# Altium schematic sheet System TopSheet v2.SchDoc("System TopSheet v2")
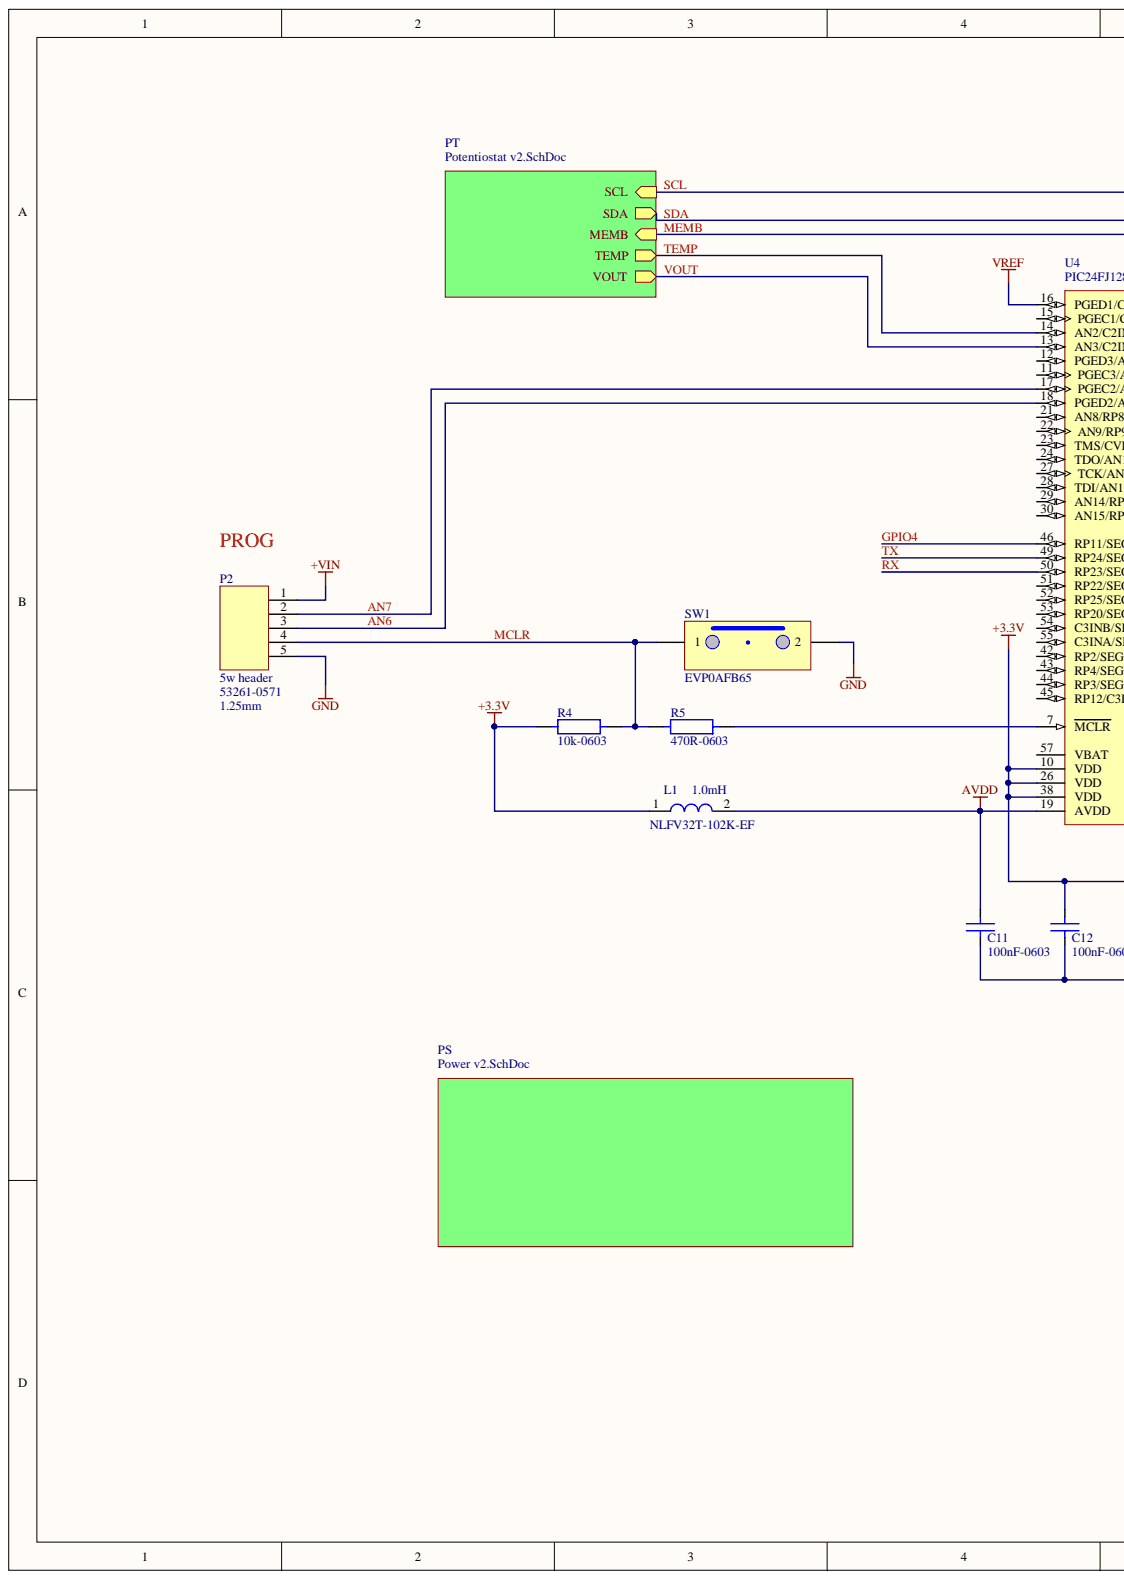
[diagram p0: System TopSheet v2.SchDoc, left]
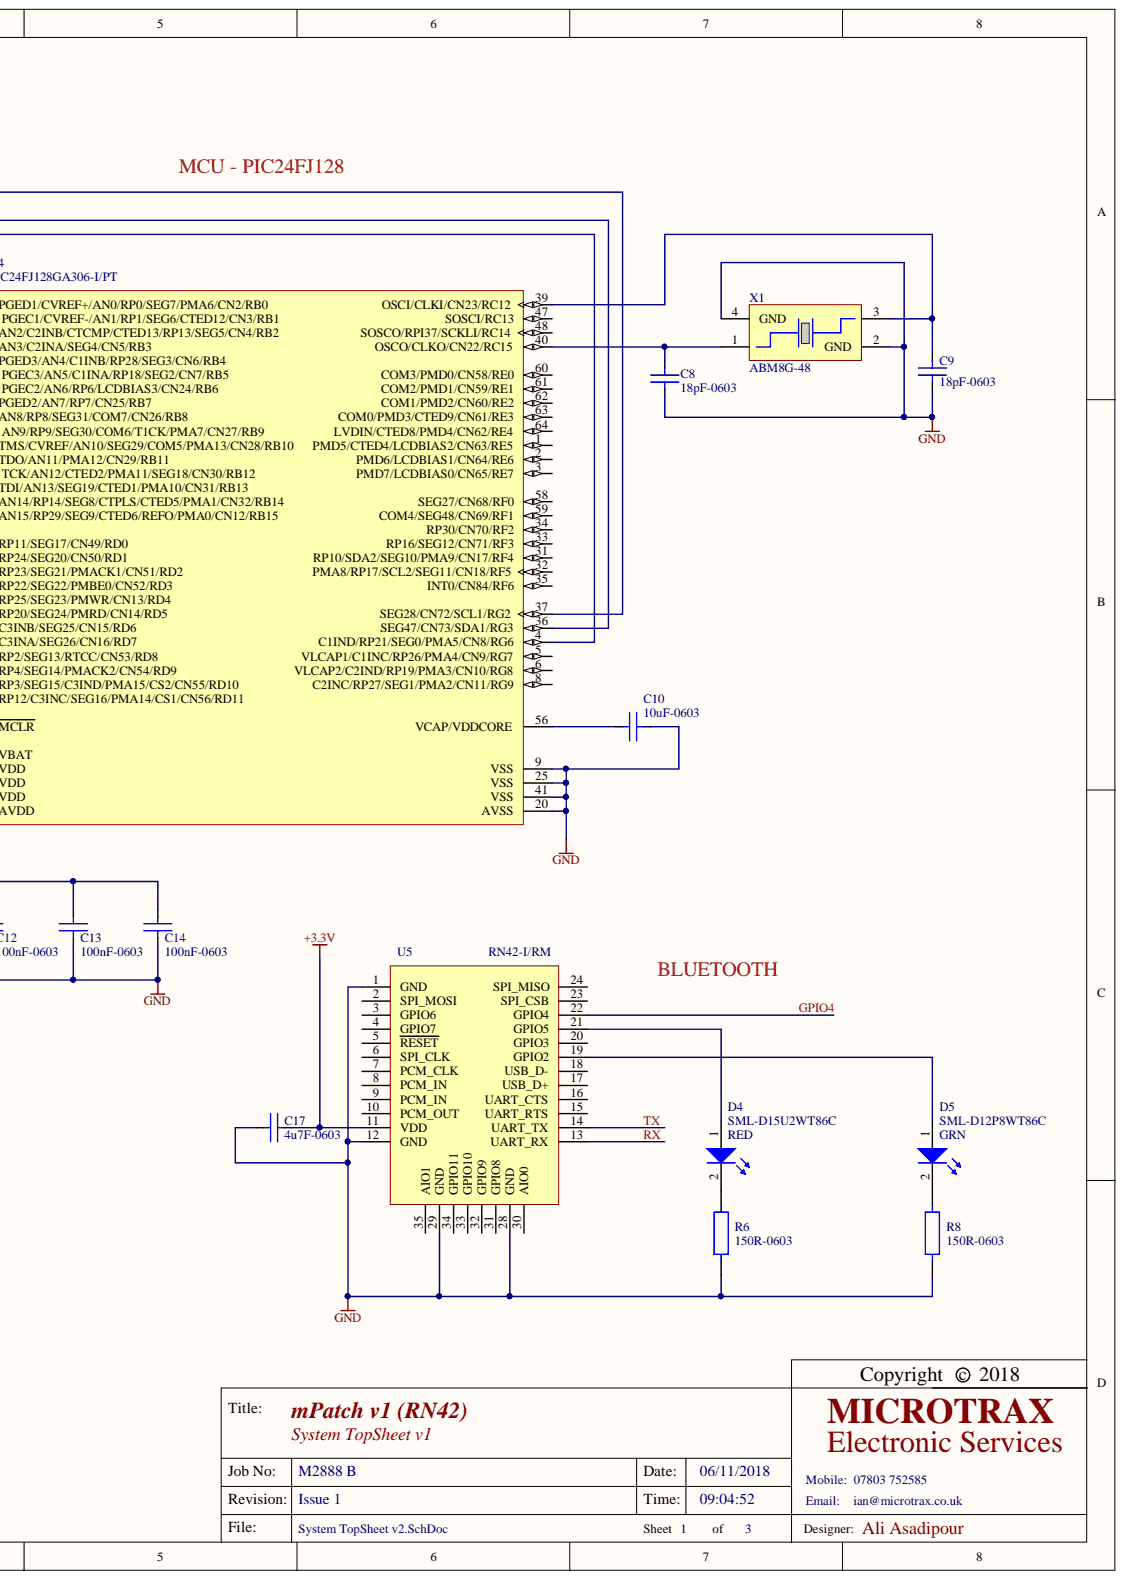
[diagram p1: System TopSheet v2.SchDoc, right]

## Parts
C8  18pF-0603  [Cap-0603]
C9  18pF-0603  [Cap-0603]
C10  10uF-0603  [Cap-0603]
C11  100nF-0603  [Cap-0603]
C12  100nF-0603  [Cap-0603]
C13  100nF-0603  [Cap-0603]
C14  100nF-0603  [Cap-0603]
C17  4u7F-0603  [Cap-0603]
D4  SML-D15U2WT86C  value RED  [LED 0603 RED]
D5  SML-D12P8WT86C  value GRN  [LED 0603 GRN]
L1  NLFV32T-102K-EF  value 1.0mH  [IND-1210-NLFV32T]
P2  5w header  Pitch: 1.25mm  Manufacturer Part Number: 53261-0571  [5W-1A-Hor-1.25]
R4  10k-0603  [Res-0603]
R5  470R-0603  [Res-0603]
R6  150R-0603  [Res-0603]
R8  150R-0603  [Res-0603]
SW1  EVP0AFB65  [SMD SW EVP0AFB65]
U4  PIC24FJ128GA306-I/PT  [MCHP-TQFP-PT64_N]  (value not drawn)
U5  RN42-I/RM  [RN41]
X1  ABM8G-48  [ABM8G]

## Nets
+3.3V: C12.1 C13.1 C14.1 C17.1 L1.1 R4.1 U4.10(VDD) U4.26(VDD) U4.38(VDD) U5.11(VDD)
+VIN: P2.1
AVDD: C11.1 L1.2 U4.19(AVDD)
GND: C8.1 C9.2 C10.2 C11.2 C12.2 C13.2 C14.2 C17.2 P2.5 R6.2 R8.2 SW1.2 U4.9(VSS) U4.20(AVSS) U4.25(VSS) U4.41(VSS) U5.1(GND) U5.12(GND) U5.28(GND) U5.29(GND) X1.2(GND) X1.4(GND)
VREF: U4.16(PGED1/CVREF+/AN0/RP0/SEG7/PMA6/CN2/RB0)
AN6: P2.3 U4.18(PGED2/AN7/RP7/CN25/RB7)
AN7: P2.2 U4.17(PGEC2/AN6/RP6/LCDBIAS3/CN24/RB6)
GPIO4: U4.46(RP11/SEG17/CN49/RD0) U5.22(GPIO4)
MCLR: P2.4 R4.2 R5.1 SW1.1
MEMB: U4.4(C1IND/RP21/SEG0/PMA5/CN8/RG6)
RX: U4.50(RP23/SEG21/PMACK1/CN51/RD2) U5.13(UART_RX)
SCL: U4.37(SEG28/CN72/SCL1/RG2)
SDA: U4.36(SEG47/CN73/SDA1/RG3)
TEMP: U4.14(AN2/C2INB/CTCMP/CTED13/RP13/SEG5/CN4/RB2)
TX: U4.49(RP24/SEG20/CN50/RD1) U5.14(UART_TX)
VOUT: U4.13(AN3/C2INA/SEG4/CN5/RB3)
NetC8_2: C8.2 U4.40(OSCO/CLKO/CN22/RC15) X1.1
NetC9_1: C9.1 U4.39(OSCI/CLKI/CN23/RC12) X1.3
NetC10_1: C10.1 U4.56(VCAP/VDDCORE)
NetD4_1: D4.1 U5.21(GPIO5)
NetD4_2: D4.2 R6.1
NetD5_1: D5.1 U5.19(GPIO2)
NetD5_2: D5.2 R8.1
NetR5_2: R5.2 U4.7(MCLR)
NetU4_1: U4.1(PMD5/CTED4/LCDBIAS2/CN63/RE5)
NetU4_2: U4.2(PMD6/LCDBIAS1/CN64/RE6)
NetU4_3: U4.3(PMD7/LCDBIAS0/CN65/RE7)
NetU4_5: U4.5(VLCAP1/C1INC/RP26/PMA4/CN9/RG7)
NetU4_6: U4.6(VLCAP2/C2IND/RP19/PMA3/CN10/RG8)
NetU4_8: U4.8(C2INC/RP27/SEG1/PMA2/CN11/RG9)
NetU4_11: U4.11(PGEC3/AN5/C1INA/RP18/SEG2/CN7/RB5)
NetU4_12: U4.12(PGED3/AN4/C1INB/RP28/SEG3/CN6/RB4)
NetU4_15: U4.15(PGEC1/CVREF-/AN1/RP1/SEG6/CTED12/CN3/RB1)
NetU4_21: U4.21(AN8/RP8/SEG31/COM7/CN26/RB8)
NetU4_22: U4.22(AN9/RP9/SEG30/COM6/T1CK/PMA7/CN27/RB9)
NetU4_23: U4.23(TMS/CVREF/AN10/SEG29/COM5/PMA13/CN28/RB10)
NetU4_24: U4.24(TDO/AN11/PMA12/CN29/RB11)
NetU4_27: U4.27(TCK/AN12/CTED2/PMA11/SEG18/CN30/RB12)
NetU4_28: U4.28(TDI/AN13/SEG19/CTED1/PMA10/CN31/RB13)
NetU4_29: U4.29(AN14/RP14/SEG8/CTPLS/CTED5/PMA1/CN32/RB14)
NetU4_30: U4.30(AN15/RP29/SEG9/CTED6/REFO/PMA0/CN12/RB15)
NetU4_31: U4.31(RP10/SDA2/SEG10/PMA9/CN17/RF4)
NetU4_32: U4.32(PMA8/RP17/SCL2/SEG11/CN18/RF5)
NetU4_33: U4.33(RP16/SEG12/CN71/RF3)
NetU4_34: U4.34(RP30/CN70/RF2)
NetU4_35: U4.35(INT0/CN84/RF6)
NetU4_42: U4.42(RP2/SEG13/RTCC/CN53/RD8)
NetU4_43: U4.43(RP4/SEG14/PMACK2/CN54/RD9)
NetU4_44: U4.44(RP3/SEG15/C3IND/PMA15/CS2/CN55/RD10)
NetU4_45: U4.45(RP12/C3INC/SEG16/PMA14/CS1/CN56/RD11)
NetU4_47: U4.47(SOSCI/RC13)
NetU4_48: U4.48(SOSCO/RPI37/SCKLI/RC14)
NetU4_51: U4.51(RP22/SEG22/PMBE0/CN52/RD3)
NetU4_52: U4.52(RP25/SEG23/PMWR/CN13/RD4)
NetU4_53: U4.53(RP20/SEG24/PMRD/CN14/RD5)
NetU4_54: U4.54(C3INB/SEG25/CN15/RD6)
NetU4_55: U4.55(C3INA/SEG26/CN16/RD7)
NetU4_57: U4.57(VBAT)
NetU4_58: U4.58(SEG27/CN68/RF0)
NetU4_59: U4.59(COM4/SEG48/CN69/RF1)
NetU4_60: U4.60(COM3/PMD0/CN58/RE0)
NetU4_61: U4.61(COM2/PMD1/CN59/RE1)
NetU4_62: U4.62(COM1/PMD2/CN60/RE2)
NetU4_63: U4.63(COM0/PMD3/CTED9/CN61/RE3)
NetU4_64: U4.64(LVDIN/CTED8/PMD4/CN62/RE4)
NetU5_2: U5.2(SPI_MOSI)
NetU5_3: U5.3(GPIO6)
NetU5_4: U5.4(GPIO7)
NetU5_5: U5.5(RESET)
NetU5_6: U5.6(SPI_CLK)
NetU5_7: U5.7(PCM_CLK)
NetU5_8: U5.8(PCM_IN)
NetU5_9: U5.9(PCM_IN)
NetU5_10: U5.10(PCM_OUT)
NetU5_15: U5.15(UART_RTS)
NetU5_16: U5.16(UART_CTS)
NetU5_17: U5.17(USB_D+)
NetU5_18: U5.18(USB_D-)
NetU5_20: U5.20(GPIO3)
NetU5_23: U5.23(SPI_CSB)
NetU5_24: U5.24(SPI_MISO)
NetU5_30: U5.30(AIO0)
NetU5_31: U5.31(GPIO8)
NetU5_32: U5.32(GPIO9)
NetU5_33: U5.33(GPIO10)
NetU5_34: U5.34(GPIO11)
NetU5_35: U5.35(AIO1)

## Library data of the parts
C8 C9 = Cap-0603: 0603 capacitor; Manufacturer Part Number: C0603C180J5GAC-TU; Manufacturer: KEMET; Voltage Rating: 50V; Tolerance: 5%; Temperature Coefficient: 30ppm/°C; Dielectric: X7R; Supplier Part Number 1: 1414620; Supplier 1: Farnell; Min Operating Temperature: -55°C; Max Operating Temperature: 125°C; Component Kind: Standard; Library Name: IG Cap_Res Symbols.SchLib
C10 = Cap-0603: 0603 capacitor; Manufacturer Part Number: GRM188R61A106KE69D; Manufacturer: Murata; Voltage Rating: 10V; Tolerance: 10%; Dielectric: X5R; Supplier Part Number 1: 2494233; Supplier 1: Farnell; Pricing: 10=0.101, 100=0.0649, 500=0.0421, 1000=0.0326, 2000=0.0271 (EUR); Max Operating Temperature: 85°C; ComponentLink2URL: http://www.mouser.com/ds/2/281/c02e-2905.pdf; ComponentLink2Description: http://www.mouser.com/ds/2/281/c02e-2905.pdf; ComponentLink1URL: http://www.farnell.com/datasheets/1955682.pdf; ComponentLink1Description: http://www.farnell.com/datasheets/1955682.pdf; Component Kind: Standard; Library Name: IG Cap_Res Symbols.SchLib
C11-C14 = Cap-0603: 0603 capacitor; Manufacturer Part Number: CC0603KRX7R9BB104; Manufacturer: YAGEO (PHYCOMP); Supplier Part Number 1: 1362556; Supplier 1: Farnell; Pricing: 10=0.0168, 100=0.0088, 500=0.0073, 1000=0.0055, 2000=0.0044, 4000=0.0038, 20000=0.0032, 40000=0.0024 (GBP); ComponentLink2URL: http://www.farnell.com/datasheets/1734573.pdf; ComponentLink2Description: http://www.farnell.com/datasheets/1734573.pdf; ComponentLink1URL: http://www.farnell.com/datasheets/70765.pdf; ComponentLink1Description: http://www.farnell.com/datasheets/70765.pdf; Component Kind: Standard; Library Name: IG Cap_Res Symbols.SchLib
C17 = Cap-0603: 0603 capacitor; Manufacturer Part Number: CC0603KRX5R6BB475; Manufacturer: Yageo; Voltage Rating: 10V; Tolerance: 10%; Dielectric: X5R; Supplier Part Number 1: 1458898; Supplier 1: Farnell; Pricing: 10=0.1, 100=0.0427, 500=0.0321, 1000=0.0249, 2000=0.0247, 4000=0.0178, 20000=0.0156 (EUR); ComponentLink2URL: https://datasheet.ciiva.com/8033/1525783-8033802.pdf; ComponentLink2Description: https://datasheet.ciiva.com/8033/1525783-8033802.pdf; ComponentLink1URL: http://www.farnell.com/datasheets/1955682.pdf; ComponentLink1Description: http://www.farnell.com/datasheets/1955682.pdf; Component Kind: Standard; Library Name: IG Cap_Res Symbols.SchLib
D4 = Led SML-D15U2WT86C: RED 0603 LED, 20mA; Manufacturer: ROHM; Supplier Part Number 1: 2687495; Supplier 1: Farnell; Pricing: 1=0.145, 10=0.135, 100=0.126, 500=0.118, 1000=0.111, 3000=0.1 (GBP); Manufacturer Part Number: SML-D15U2WT86C; ComponentLink1URL: http://www.farnell.com/datasheets/2186941.pdf; ComponentLink1Description: http://www.farnell.com/datasheets/2186941.pdf; Component Kind: Standard; Library Name: IG Misc Symbols.SchLib
D5 = Led SML-D12P8WT86C: GRN 0603 LED, 20mA; Manufacturer: ROHM; Supplier Part Number 1: 2687486; Supplier 1: Farnell; Pricing: 1=0.085, 10=0.079, 100=0.073, 500=0.069, 1000=0.065, 3000=0.058 (GBP); Manufacturer Part Number: SML-D12P8WT86C; ComponentLink1URL: http://www.farnell.com/datasheets/2186940.pdf; ComponentLink1Description: http://www.farnell.com/datasheets/2186940.pdf; Component Kind: Standard; Library Name: IG Misc Symbols.SchLib
L1 = IND-NLFV32T: High frequency inductor, 20mA, 1210; Manufacturer: TDK; Supplier Part Number 1: 2407460; Supplier 1: Farnell; Pricing: 1=0.255, 60=0.187, 200=0.161, 500=0.106, 1000=0.0982, 2000=0.0899, 10000=0.0836, 20000=0.0743 (GBP); Manufacturer Part Number: NLFV32T-102K-EF; Library Name: IG Misc Symbols.SchLib; ComponentLink1URL: http://www.farnell.com/datasheets/1805092.pdf; ComponentLink1Description: http://www.farnell.com/datasheets/1805092.pdf; Component Kind: Standard
P2 = 5w terminal: Manufacturer: Molex; Voltage Rating: 125V; Insulation Resistance: 100MR; Gender: Male; Current Rating: 1A; Supplier Part Number 1: 1125360; Supplier 1: Farnell; Pricing: 1=0.922, 30=0.829, 80=0.736, 250=0.616 (EUR); Orientation: Right Angle; Min Operating Temperature: -40°C; Max Operating Temperature: 85°C; ComponentLink2URL: https://datasheet.ciiva.com/6083/1688141-6083001.pdf; ComponentLink2Description: https://datasheet.ciiva.com/6083/1688141-6083001.pdf; ComponentLink1URL: http://www.farnell.com/datasheets/1024885.pdf; ComponentLink1Description: http://www.farnell.com/datasheets/1024885.pdf; Component Kind: Standard; Library Name: IG Connector Symbols.SchLib
R4 = Res-0603: 0603 resistor; Manufacturer Part Number: RC0603FR-0710KL; Manufacturer: YAGEO (PHYCOMP); Supplier Part Number 1: 9238603; Supplier 1: Farnell; Pricing: 10=0.0053, 400=0.0045, 750=0.004, 1250=0.0036, 2500=0.0032 (GBP); ComponentLink1URL: http://www.farnell.com/datasheets/1868787.pdf; ComponentLink1Description: http://www.farnell.com/datasheets/1868787.pdf; Component Kind: Standard; Library Name: IG Cap_Res Symbols.SchLib
R5 = Res-0603: 0603 resistor; Manufacturer Part Number: RC0603FR-07470RL.; Manufacturer: YAGEO (PHYCOMP); Supplier Part Number 1: 9238441; Supplier 1: Farnell; Pricing: 10=0.0051, 400=0.0043, 750=0.0038, 1250=0.0034, 2500=0.003 (GBP); ComponentLink1URL: http://www.farnell.com/datasheets/1868787.pdf; ComponentLink1Description: http://www.farnell.com/datasheets/1868787.pdf; Component Kind: Standard; Library Name: IG Cap_Res Symbols.SchLib
R6 R8 = Res-0603: 0603 resistor; Manufacturer Part Number: CRCW0603150RFKEAHP; Manufacturer: VISHAY; Supplier Part Number 1: 1738893; Supplier 1: Farnell; Pricing: 10=0.0725, 100=0.0315, 500=0.027, 1000=0.0203, 2500=0.0174, 5000=0.0145, 25000=0.0118, 50000=0.0114 (GBP); ComponentLink1URL: http://www.farnell.com/datasheets/2140397.pdf; ComponentLink1Description: http://www.farnell.com/datasheets/2140397.pdf; Component Kind: Standard; Library Name: IG Cap_Res Symbols.SchLib
SW1 = SMD Push switch EVP0AFB65: Push to make, momentray action switch; Manufacturer Part Number: EVP-0AFB65; Manufacturer: Panasonic; Voltage Rating (DC): 15V; Throw Configuration: SPST; Operating Force: 1.6N; Current Rating: 20mA; Supplier Part Number 1: 2425606; Supplier 1: Farnell; Pricing: 1=0.392, 10=0.361, 100=0.332 (EUR); Min Operating Temperature: -40°C; Max Operating Temperature: 85°C; Library Name: IG Misc Symbols.SchLib; ComponentLink2URL: https://datasheet.ciiva.com/24142/atk0000ce31-45200-24142833.pdf; ComponentLink2Description: https://datasheet.ciiva.com/24142/atk0000ce31-45200-24142833.pdf; ComponentLink1URL: https://datasheet.ciiva.com/7987/ta-1143-7987108.pdf; ComponentLink1Description: https://datasheet.ciiva.com/7987/ta-1143-7987108.pdf; Component Kind: Standard
U4 = PIC24FJ128GA306-I/PT: General Purpose, 16-Bit Flash Microcontroller with LCD Controller and nanoWatt XLP Technology, 16 MIPS, 53 I/O, EPMP, -40 to 85 degC, 64-pin TQFP (PT64), Tray; Manufacturer: Microchip; Supplier Part Number 4: 579-24FJ128GA306IPT; Supplier Part Number 3: 39T6753; Supplier Part Number 2: 2076546; Supplier Part Number 1: PIC24FJ128GA306-I/PT-ND; Supplier 4: Mouser; Supplier 3: Newark; Supplier 2: Farnell; Supplier 1: Digi-Key; PartNumber: PIC24FJ128GA306-I/PT; PackageVersion: C04-085B; PackageReference: TQFP-PT64; PackageDescription: 64-Lead Plastic Thin Quad FlatPack, 10 x 10 mm Body, 0.5 mm Pitch; Mounting Technology: Surface Mount; Library Name: Microchip PIC24F.IntLib; DatasheetVersion: Rev. F; ComponentLink4URL: http://www.microchip.com/PIC24FJ128GA306; ComponentLink4Description: Product URL; ComponentLink3URL: http://www.microchip.com/stellent/groups/techpub_sg/documents/packagingspec/en012702.pdf; ComponentLink3Description: Package Specification; ComponentLink2URL: http://ww1.microchip.com/downloads/en/DeviceDoc/39996f.pdf; ComponentLink2Description: Datasheet; ComponentLink1URL: http://www.microchip.com; ComponentLink1Description: Manufacturer URL; Component Kind: Standard
U5 = RN42: Bluetooth module; Manufacturer: Microchip; Sensitivity: -80dBm; Operating Supply Voltage: 3.3V; Min Supply Voltage: 3V; Max Supply Voltage: 3.6V; Interface: SPI,UART; Frequency: 2.4GHz; Data Rate: 3Mbps; Current - Transmitting: 30mA; Current - Receiving: 25mA; Supplier Part Number 1: 2143310; Supplier 1: Farnell; Number of Pins: 35; Mount: Surface Mount; Min Operating Temperature: -40°C; Max Operating Temperature: 85°C; Manufacturer Part Number: RN42-I/RM; ComponentLink5URL: http://www.farnell.com/datasheets/1728644.pdf; ComponentLink5Description: http://www.farnell.com/datasheets/1728644.pdf; ComponentLink4URL: http://uk.rs-online.com/webdocs/11a8/0900766b811a8f51.pdf; ComponentLink4Description: http://uk.rs-online.com/webdocs/11a8/0900766b811a8f51.pdf; ComponentLink3URL: http://ww1.microchip.com/downloads/en/DeviceDoc/rn-42-ds-v2.3r.pdf; ComponentLink3Description: http://ww1.microchip.com/downloads/en/DeviceDoc/rn-42-ds-v2.3r.pdf; ComponentLink2URL: https://datasheet.ciiva.com/15280/htmls4-15280509.pdf; ComponentLink2Description: https://datasheet.ciiva.com/15280/htmls4-15280509.pdf; ComponentLink1URL: http://www.farnell.com/datasheets/1948358.pdf; ComponentLink1Description: http://www.farnell.com/datasheets/1948358.pdf; Component Kind: Standard; Library Name: IG Misc Symbols.SchLib
X1 = Crystal ABM8G: SMD crystal; Manufacturer Part Number: ABM8G-48.000MHZ-18-D2Y-T; Manufacturer: Abracon; Load Capacitance: 18pF; Frequency Tolerance: 20ppm; Frequency Stability: 30ppm; Frequency: 48MHz; ESR (Equivalent Series Resistance): 50R; Supplier Part Number 1: 2101342; Supplier 1: Farnell; Pricing: 1=0.812, 50=0.503, 100=0.498, 250=0.497, 500=0.496, 1000=0.495, 5000=0.494 (EUR); ComponentLink1URL: https://datasheet.ciiva.com/855/abm8g-855820.pdf; ComponentLink1Description: https://datasheet.ciiva.com/855/abm8g-855820.pdf; Component Kind: Standard; Library Name: IG Misc Symbols.SchLib
